# Altium schematic sheet Power_Supply.SchDoc
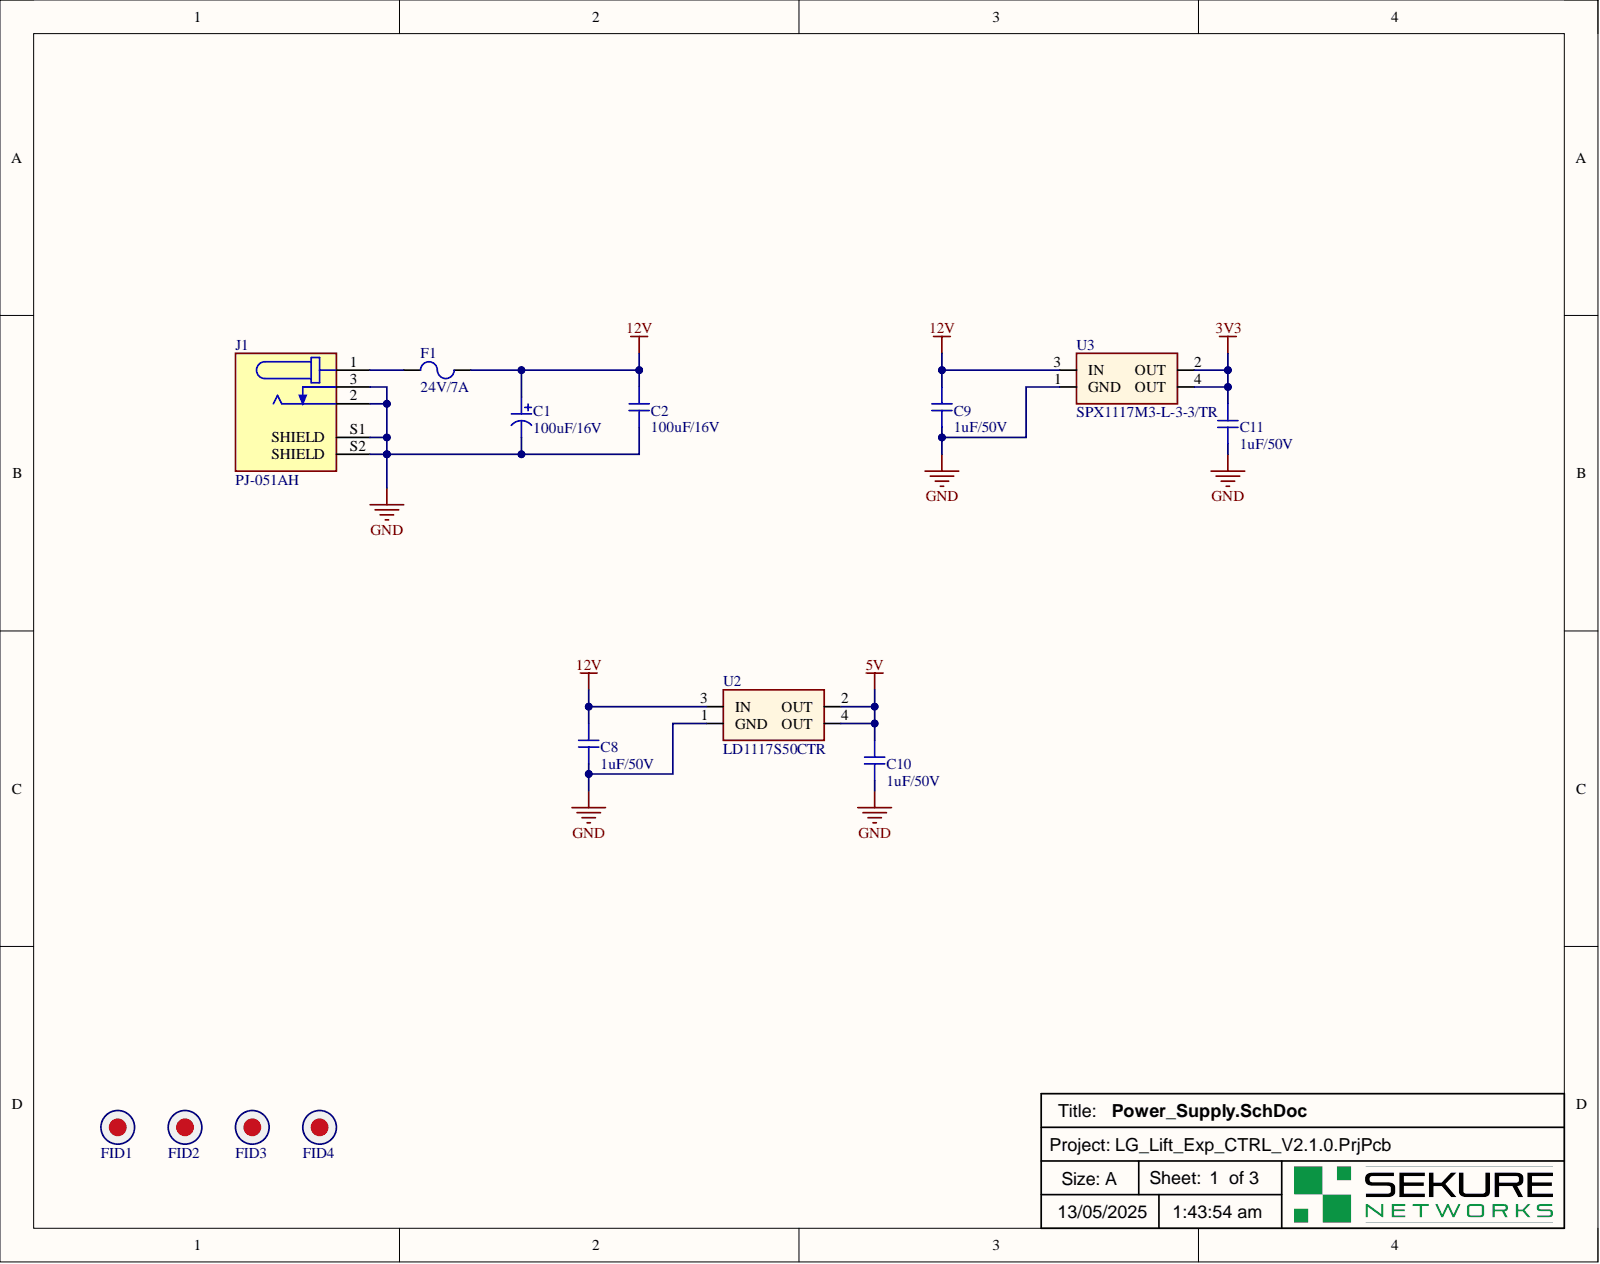
[diagram p0: Power_Supply.SchDoc]

## Parts
C1  value 100uF/16V  [CAP SMD 6.6 x 6.6]  (hidden comment: Capacitor)
C2  value 100uF/16V  [CAP 1210]  (hidden comment: Ceramic Capacitor)
C8  value 1uF/50V  [CAP 0603]  (hidden comment: Ceramic Capacitor)
C9  value 1uF/50V  [CAP 0603]  (hidden comment: Ceramic Capacitor)
C10  value 1uF/50V  [CAP 0603]  (hidden comment: Ceramic Capacitor)
C11  value 1uF/50V  [CAP 0603]  (hidden comment: Ceramic Capacitor)
F1  value 24V/7A  [2920L700/24SLER]  (hidden comment: Fuse)
FID1  Fiducal marks  [Fiducial mark]  (value not drawn)  (no BOM)
FID2  Fiducal marks  [Fiducial mark]  (value not drawn)  (no BOM)
FID3  Fiducal marks  [Fiducial mark]  (value not drawn)  (no BOM)
FID4  Fiducal marks  [Fiducial mark]  (value not drawn)  (no BOM)
J1  value PJ-051AH  [PJ-051AH]  (hidden comment: Connector)
U2  value LD1117S50CTR  Max Output Voltage: 5V  [SOT-223]  (hidden comment: Regulator)
U3  value SPX1117M3-L-3-3/TR  [SOT-223]  (hidden comment: Regulator)

## Nets
3V3: C11.2 U3.2(OUT) U3.4(OUT)
5V: C10.1 U2.2(OUT) U2.4(OUT)
12V: C1.1 C2.2 C8.2 C9.2 F1.2 U2.3(IN) U3.3(IN)
GND: C1.2 C2.1 C8.1 C9.1 C10.2 C11.1 J1.2 J1.3 J1.S1(SHIELD) J1.S2(SHIELD) U2.1(GND) U3.1(GND)
NetF1_1: F1.1 J1.1

Not connected: FID1 0; FID2 0; FID3 0; FID4 0

## Library data of the parts
C1 = A765EB107M1CLAE025: Aluminum Electrolytic Capacitor, Polarized, Aluminum (solid Polymer), 16V, 20% +Tol, 20% -Tol, 100uF, Surface Mount, 2626; Manufacturer: KEMET; Capacitor Type: Aluminium; Category: Polymer Capacitors; Component Kind: Standard; ComponentLink1Description: https://datasheet.ciiva.com:443/pdfs/VipMasterIC/IC/KEME/KEME-S-A0009156844/KEME-S-A0009156844-1.pdf?src-supplier=IHS+Markit; ComponentLink1URL: https://datasheet.ciiva.com:443/pdfs/VipMasterIC/IC/KEME/KEME-S-A0009156844/KEME-S-A0009156844-1.pdf?src-supplier=IHS+Markit; ComponentLink2Description: https://datasheet.octopart.com/A765EB107M1CLAE025-KEMET-datasheet-140121990.pdf?src-supplier=Farnell; ComponentLink2URL: https://datasheet.octopart.com/A765EB107M1CLAE025-KEMET-datasheet-140121990.pdf?src-supplier=Farnell; ComponentLink3Description: http://www.farnell.com/datasheets/2095663.pdf?src-supplier=Element14; ComponentLink3URL: http://www.farnell.com/datasheets/2095663.pdf?src-supplier=Element14; ComponentLink4Description: https://api.kemet.com/component-edge/download/datasheet/A765EB107M1CLAE025.pdf?src-supplier=Digikey; ComponentLink4URL: https://api.kemet.com/component-edge/download/datasheet/A765EB107M1CLAE025.pdf?src-supplier=Digikey; ComponentLink5Description: http://www.kemet.com/docfinder?Partnumber=A765EB107M1CLAE025&src-supplier=Digikey; ComponentLink5URL: http://www.kemet.com/docfinder?Partnumber=A765EB107M1CLAE025&src-supplier=Digikey; ComponentLink6Description: https://datasheet.octopart.com/A765EB107M1CLAE025-KEMET-datasheet-74830868.pdf?src-supplier=Newark; ComponentLink6URL: https://datasheet.octopart.com/A765EB107M1CLAE025-KEMET-datasheet-74830868.pdf?src-supplier=Newark; ComponentLink7Description: https://datasheet.octopart.com/A765EB107M1CLAE025-KEMET-datasheet-165572898.pdf?src-supplier=TME; ComponentLink7URL: https://datasheet.octopart.com/A765EB107M1CLAE025-KEMET-datasheet-165572898.pdf?src-supplier=TME; ComponentLink8Description: https://datasheet.octopart.com/A765EB107M1CLAE025-KEMET-datasheet-138896607.pdf?src-supplier=Electro+Sonic; ComponentLink8URL: https://datasheet.octopart.com/A765EB107M1CLAE025-KEMET-datasheet-138896607.pdf?src-supplier=Electro+Sonic; Dielectric Material: Aluminium; DigiKey: 399-13672-1-ND; Library Name: Radial Capacitors.IntLib; P/N: A765EB107M1CLAE025; Pins: 2; Pricing: 1=0.69, 10=0.482, 50=0.4062, 100=0.3307, 500=0.27556 (USD); Reach SVHC Compliant: Yes; RoHS: Yes; RoHS Compliant: Yes; Stock: 4291; Supplier: Digikey
C2 = 1210YD107MAT2A: Ceramic Capacitor, Multilayer, Ceramic, 16V, 20% +Tol, 20% -Tol, X5R, 15% TC, 100uF, Surface Mount, 1210; Manufacturer: Kyocera AVX; Multilayer: Yes; Capacitor Type: Ceramic; Case/Package: 1210; Category: Ceramic Capacitors; Component Kind: Standard; ComponentLink1Description: https://datasheet.ciiva.com:443/pdfs/VipMasterIC/IC/AVXC/AVXC-S-A0008940919/AVXC-S-A0008940919-1.pdf?src-supplier=IHS+Markit; ComponentLink1URL: https://datasheet.ciiva.com:443/pdfs/VipMasterIC/IC/AVXC/AVXC-S-A0008940919/AVXC-S-A0008940919-1.pdf?src-supplier=IHS+Markit; ComponentLink2Description: http://datasheets.avx.com/cx5r.pdf?src-supplier=Digi-Key; ComponentLink2URL: http://datasheets.avx.com/cx5r.pdf?src-supplier=Digi-Key; ComponentLink3Description: http://catalogs.avx.com/SurfaceMount.pdf?src-supplier=Digi-Key; ComponentLink3URL: http://catalogs.avx.com/SurfaceMount.pdf?src-supplier=Digi-Key; Dielectric Material: Ceramic; DigiKey: 478-11408-2-ND; JESD-609 Code: e3; Library Name: Ceramic Capacitors.IntLib; Max Operating Temperature: 85°C; Min Operating Temperature: -55°C; Mounting Technology: Surface Mount; P/N: 1210YD107MAT2A; Package Shape: Rectangular; Packaging: Tape and Reel; Pins: 2; Pricing: 500=1.70614, 1000=1.58848, 2500=1.52964, 5000=1.50023 (USD); Rated DC Voltage (URdc): 16V; Reach SVHC Compliant: Yes; RoHS: Yes; RoHS Compliant: Yes; Stock: 13000; Supplier: Digi-Key; Temperature Characteristics Code: X5R; Terminal Finish: Nickel; Termination: Wraparound; Tolerance  (fill in as +-): 20%; Tolerance (fill in as +-): 20%
C8-C11 = UMK107AB7105KA-T: CAP CER  1uF 50 V ±10% Tolerance X7R SMT; Manufacturer: Taiyo Yuden; Voltage Rating: 50V; Capacitance: 1uF; Case Code (Imperial): 0603; Case Code (Metric): 1608; Case/Package: 0603; Component Kind: Standard; ComponentLink1Description: https://datasheet.ciiva.com/6257/umk107ab7105ka-t-ss-6257600.pdf?src-supplier=Digi-Key; ComponentLink1URL: https://datasheet.ciiva.com/6257/umk107ab7105ka-t-ss-6257600.pdf?src-supplier=Digi-Key; ComponentLink2Description: https://datasheet.ciiva.com/15922/umk107ab7105ka-t-pdf-15922601.pdf?src-supplier=Onlinecomponents.com; ComponentLink2URL: https://datasheet.ciiva.com/15922/umk107ab7105ka-t-pdf-15922601.pdf?src-supplier=Onlinecomponents.com; ComponentLink3Description: https://datasheet.ciiva.com/30137/76umk107ab7105ka-t-30137806.pdf?src-supplier=Onlinecomponents.com; ComponentLink3URL: https://datasheet.ciiva.com/30137/76umk107ab7105ka-t-30137806.pdf?src-supplier=Onlinecomponents.com; Depth: 0.8mm; Dielectric: X7R; DigiKey: 587-3247-1-ND; Halogen Free: Halogen Free; Height: 0.8mm; Length: 1.6mm; Library Name: Ceramic Capacitors.IntLib; Max Operating Temperature: 125°C; Min Operating Temperature: -55°C; Mount: Surface Mount; P/N: UMK107AB7105KA-T; Package Quantity: 1; Packaging: Tape and Reel; Pricing 1: 1=0.25000, 10=0.17500, 100=0.09890, 500=0.06982, 1000=0.06110 (USD); Radiation Hardening: No; RoHS: Yes; Stock 1: 799212; Supplier 1: Digi-Key; Termination: SMD/SMT; Thickness: 0.037inch; Tolerance: 10%; Voltage Rating (DC): 50V; Width: 0.031inch
F1 = 2920L700/24SLER: PTC RESETTABLE FUSE, 24VDC, 2920; PPTC C; Case Style: 2920 (7351 Metric); Product Range: PolySwitch Low Rho; Manufacturer: Littelfuse; Category: PTC Resettable Fuses; Component Kind: Standard; DigiKey: 18-2920L700/24SLERCT-ND; Library Name: Diode.IntLib; P/N: 2920L700/24SLER; Pricing: 1=3.05, 5=2.95, 10=2.848, 50=2.2376, 100=1.8307, 250=1.77988, 500=1.5256, 1000=1.4239 (USD); RoHS: Yes; Stock: 2537; Supplier: Digikey
FID1-FID4 = Fiducial mark: Fiducial mark mini  in top placement.; Number: 1; Library Name: Connectors.IntLib
J1 = PJ-051AH: 2.0 x 6.0 mm, 5.0 A, Horizontal, Through Hole, Shielded, Dc Power Jack Connector; Manufacturer: CUI Devices; Category: Power Connectors; Component Kind: Standard; ComponentLink1Description: https://www.cuidevices.com/product/resource/digikeypdf/pj-051ah.pdf?src-supplier=Digikey; ComponentLink1URL: https://www.cuidevices.com/product/resource/digikeypdf/pj-051ah.pdf?src-supplier=Digikey; ComponentLink2Description: https://datasheet.octopart.com/PJ-051AH-CUI-Devices-datasheet-137760691.pdf?src-supplier=Future+Electronics; ComponentLink2URL: https://datasheet.octopart.com/PJ-051AH-CUI-Devices-datasheet-137760691.pdf?src-supplier=Future+Electronics; ComponentLink3Description: https://datasheet.octopart.com/PJ-051AH-CUI-Devices-datasheet-141000361.pdf?src-supplier=Master+Electronics; ComponentLink3URL: https://datasheet.octopart.com/PJ-051AH-CUI-Devices-datasheet-141000361.pdf?src-supplier=Master+Electronics; DigiKey: CP-051AH-ND; Library Name: Connectors.IntLib; P/N: PJ-051AH; Pricing: 1=0.63, 10=0.532, 25=0.5108, 50=0.4998, 100=0.4781, 250=0.43464, 500=0.39118, 1000=0.38261 (USD); RoHS: Yes; Stock: 71631; Supplier: Digikey
U2 = LD1117S50CTR: IC REG LDO 5V 0.8A SOT223; Manufacturer: STMicroelectronics; Accuracy: 1%; Dropout Voltage: 1.1V; Input Bias Current: 5mA; Max Input Voltage: 15V; Max Output Current: 1.3A; Max Power Dissipation: 12W; Min Input Voltage: 6.5V; Number of Outputs: 1; Output Current: 800mA; Output Type: Fixed; Polarity: Positive; Quiescent Current: 10mA; Case/Package: SOT-223; Category: Voltage Regulators - Linear; Component Kind: Standard; ComponentLink1Description: https://datasheet.ciiva.com/1511/1776449-1511574.pdf?src-supplier=Element14; ComponentLink1URL: https://datasheet.ciiva.com/1511/1776449-1511574.pdf?src-supplier=Element14; ComponentLink2Description: https://datasheet.ciiva.com/7992/1776449-7992622.pdf?src-supplier=Element14; ComponentLink2URL: https://datasheet.ciiva.com/7992/1776449-7992622.pdf?src-supplier=Element14; ComponentLink3Description: https://datasheet.ciiva.com/2041/cd00000544-2041924.pdf?src-supplier=Digi-Key; ComponentLink3URL: https://datasheet.ciiva.com/2041/cd00000544-2041924.pdf?src-supplier=Digi-Key; ComponentLink4Description: https://datasheet.ciiva.com/2041/cd00000544-2041923.pdf?src-supplier=Digi-Key; ComponentLink4URL: https://datasheet.ciiva.com/2041/cd00000544-2041923.pdf?src-supplier=Digi-Key; ComponentLink5Description: https://datasheet.ciiva.com/2041/cd00000544-2041925.pdf?src-supplier=Digi-Key; ComponentLink5URL: https://datasheet.ciiva.com/2041/cd00000544-2041925.pdf?src-supplier=Digi-Key; ComponentLink6Description: https://datasheet.ciiva.com/16457/cd00000544-16457718.pdf?src-supplier=Future+Electronics; ComponentLink6URL: https://datasheet.ciiva.com/16457/cd00000544-16457718.pdf?src-supplier=Future+Electronics; DigiKey: 497-1243-1-ND; Height: 1.8mm; Lead Free: Lead Free; Length: 6.5mm; Library Name: Regulators.IntLib; Max Operating Temperature: 125°C; Max Supply Voltage: 15V; Min Operating Temperature: -40°C; Min Output Voltage: 5V; Min Supply Voltage: 6.5V; Mount: Surface Mount; Nominal Output Voltage: 5V; Number of Pins: 4; Number of Regulators: 1; Output Voltage: 5V; Output Voltage Accuracy: 1%; P/N: LD1117S50CTR; Package Quantity: 1; Packaging: Tape and Reel; Power Dissipation: 12W; Pricing 1: 1=0.67000, 10=0.57300, 25=0.53480, 100=0.42800, 250=0.39740, 500=0.33628, 1000=0.25985 (USD); Radiation Hardening: No; REACH SVHC: No SVHC; RoHS: Yes; RoHS Compliant: Yes; Series: -; Stock 1: 26; Supplier 1: Digi-Key; Termination: SMD/SMT; Weight: 0.01lb; Width: 3.5mm
U3 = SPX1117M3-L-3-3/TR: Linear Voltage Regulator IC Positive Fixed 1 Output 800mA SOT-223-3; Manufacturer: MaxLinear, Inc.; Accuracy: 1%; Dropout Voltage: 1.3V; Input Bias Current: 4mA; Max Input Voltage: 15V; Max Output Voltage: 3.3V; Min Input Voltage: 3.8V; Number of Outputs: 1; Case/Package: SOT-223; Category: Voltage Regulators - Linear; Component Kind: Standard; ComponentLink1Description: https://datasheet.ciiva.com/2064/reg1117-2064563.pdf?src-supplier=Digi-Key; ComponentLink1URL: https://datasheet.ciiva.com/2064/reg1117-2064563.pdf?src-supplier=Digi-Key; DigiKey: 1016-1848-2-ND; Lead Free: Lead Free; Library Name: Regulators.IntLib; Max Operating Temperature: 125°C; Max Output Current: 800mA; Min Current Limit: 800mA; Min Operating Temperature: 0°C; Min Output Voltage: 3.3V; Nominal Output Voltage: 3.3V; Number of Pins: 4; Number of Regulators: 1; Output Current: 800mA; Output Type: Fixed; Output Voltage: 3.3V; Output Voltage Accuracy: 1%; P/N: SPX1117M3-L-3-3/TR; Package Quantity: 80; Packaging: Rail/Tube; Polarity: Positive; Pricing 1: 1=3.08000, 10=2.76700, 25=2.61040, 100=2.22390, 250=2.08820, 500=1.82718, 1000=1.72375 (USD); Quiescent Current: 4mA; Radiation Hardening: No; REACH SVHC: No SVHC; RoHS: Yes; RoHS Compliant: Yes; Stock 1: 3710; Supplier 1: Digi-Key
